# Altium schematic sheet 5_A9912FH-PCB2-PFC_MCU BOARD _V1.SchDoc("5_A9912FH-PCB2-PFC_MCU BOARD _V1")
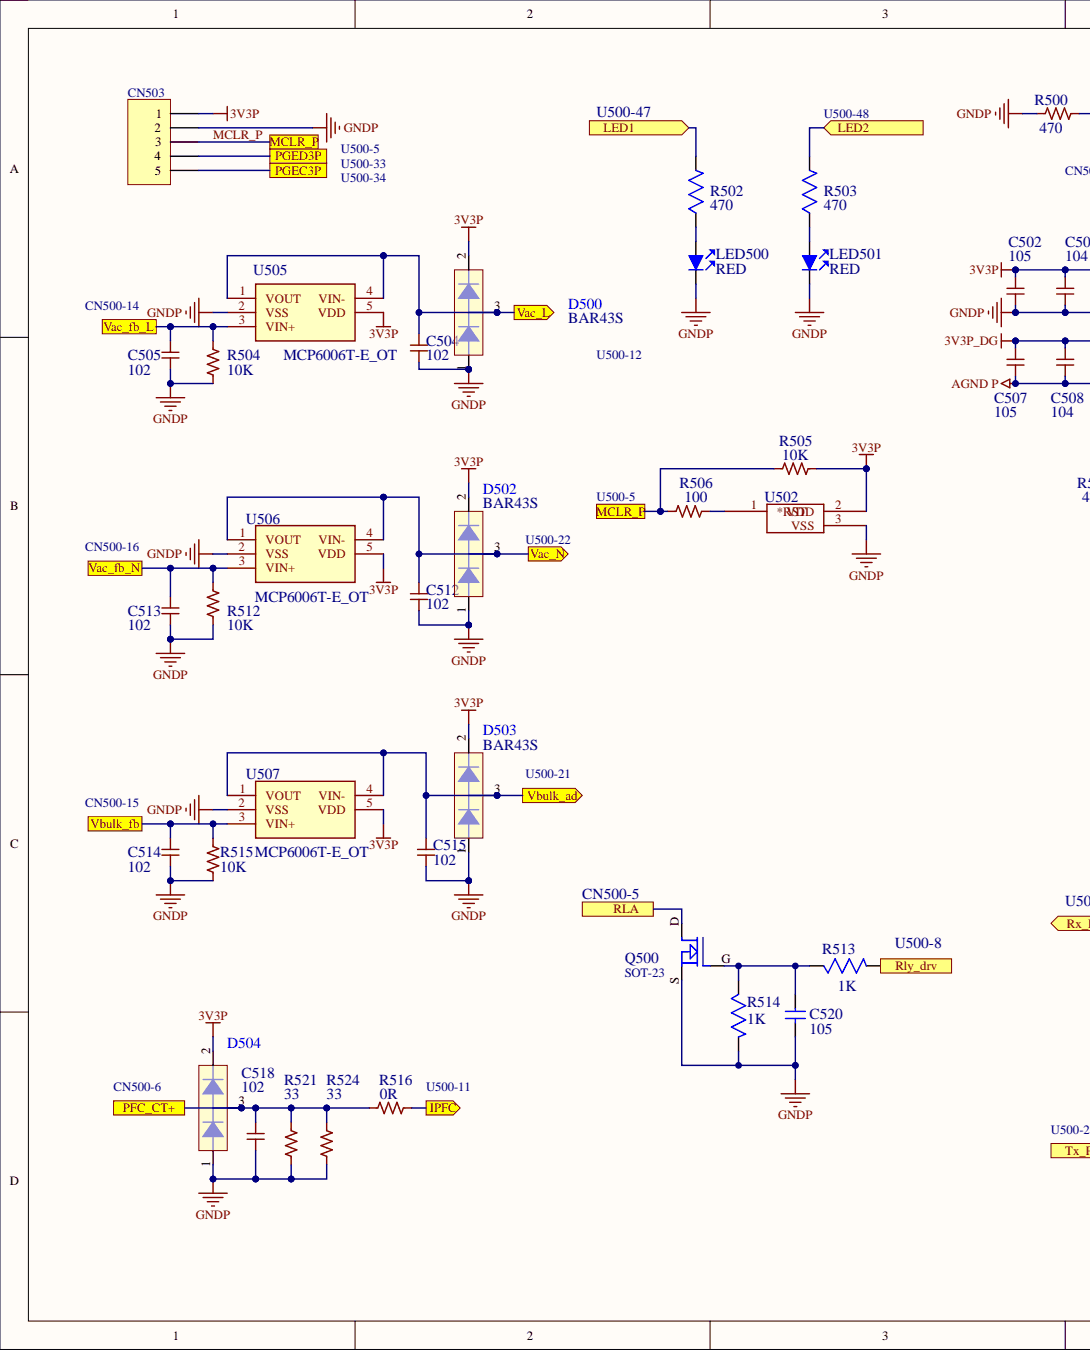
[diagram p0: 5_A9912FH-PCB2-PFC_MCU BOARD _V1.SchDoc, left]
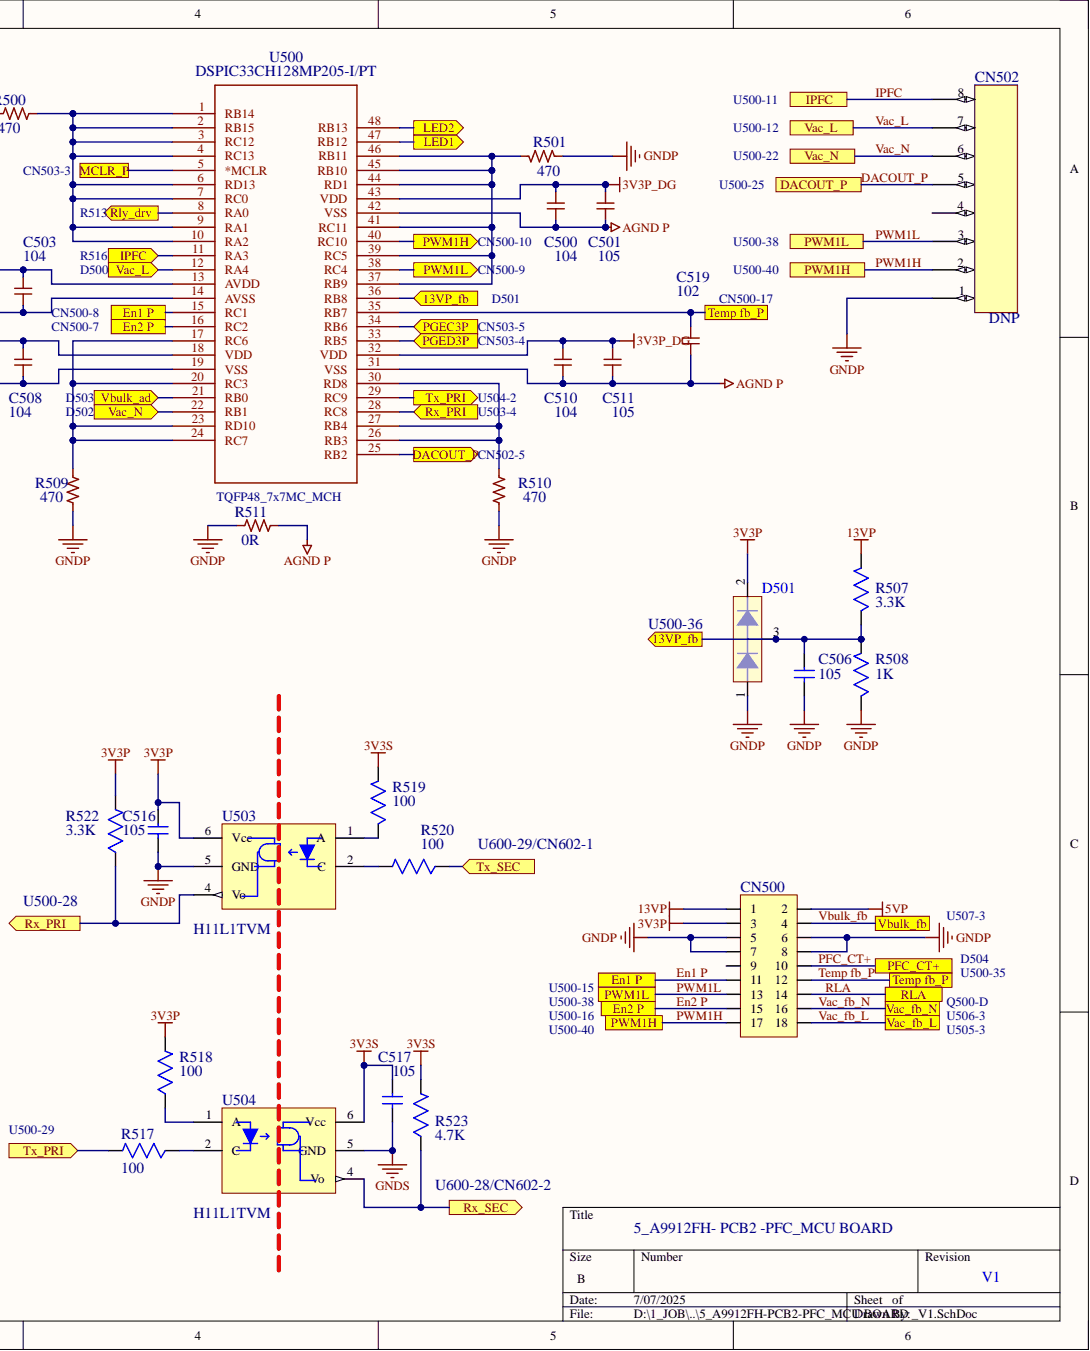
[diagram p1: 5_A9912FH-PCB2-PFC_MCU BOARD _V1.SchDoc, right]

## Parts
C500  value 104  (hidden comment: 1uF)
C501  value 105  (hidden comment: 0.1uF)
C502  value 105  (hidden comment: 0.1uF)
C503  value 104  (hidden comment: 1uF)
C504  value 102  (hidden comment: 1n)
C505  102
C506  value 105  [CS-0805]  (hidden comment: CAP_RC0603)
C507  value 105  (hidden comment: 0.1uF)
C508  value 104  (hidden comment: 1uF)
C510  value 104  (hidden comment: 1uF)
C511  value 105  (hidden comment: 0.1uF)
C512  value 102  (hidden comment: 1n)
C513  value 102  (hidden comment: 1n)
C514  value 102  (hidden comment: 1n)
C515  value 102  (hidden comment: 1n)
C516  value 105  [CS-0805]  (hidden comment: 0603_1uF 25V X7R)
C517  value 105  [CS-0805]  (hidden comment: 0603_1uF 25V X7R)
C518  value 102  (hidden comment: 1n)
C519  value 102  (hidden comment: 1n)
C520  value 105  [CS-0805]  (hidden comment: 0603_1uF 25V X7R)
CN500  CON18D  [CND-BH-P2_54-18H]  (value not drawn)
CN502  value DNP  [PN-P2_54-8]  (hidden comment: SIP_8_RA)
CN503  CON5  [PN-P2_54-5]  (value not drawn)
D500  BAR43S  [DS-SOT23-MMBD4148]
D501  BAR43S  [DS-SOT23-MMBD4148]  (value not drawn)
D502  BAR43S  [DS-SOT23-MMBD4148]
D503  BAR43S  [DS-SOT23-MMBD4148]
D504  BAR43S  [DS-SOT23-MMBD4148]  (value not drawn)
LED500  RED  [LEDS-HUB35A]
LED501  RED  [LEDS-HUB35A]
Q500  MOS-N  [MOS-SOT23 -GDS]  (value not drawn)
R500  470
R501  470
R502  value 470  [RS-0805]  (hidden comment: RC_0603)
R503  value 470  [RS-0805]  (hidden comment: RC_0603)
R504  10K
R505  10K
R506  100
R507  value 3.3K  [RS-0805]  (hidden comment: RC_0603)
R508  value 1K  [RS-0805]  (hidden comment: RC_0603)
R509  470
R510  470
R511  0  value 0R
R512  10K
R513  value 1K  [RS-0805]  (hidden comment: RC_0603)
R514  value 1K  [RS-0805]  (hidden comment: RC_0603)
R515  10K
R516  0  value 0R
R517  value 100  [RS-0805]  (hidden comment: RC_0603)
R518  value 100  [RS-0805]  (hidden comment: RC_0603)
R519  value 100  [RS-0805]  (hidden comment: RC_0603)
R520  value 100  [RS-0805]  (hidden comment: RC_0603)
R521  33
R522  value 3.3K  [RS-0805]  (hidden comment: RC_0603)
R523  value 4.7K  [RS-0805]  (hidden comment: RC_0603)
R524  33
U500  DSPIC33CH128MP205-I/PT  [TQFP48_7x7MC_MCH]
U502  MCP121T-300E_TT  [SOT-23_MC_MCH]  (value not drawn)
U503  H11L1TVM  [ICS-PDIP-6]
U504  H11L1TVM  [ICS-PDIP-6]
U505  MCP6006T-E_OT  [SOT95P280X145-5N]
U506  MCP6006T-E_OT  [SOT95P280X145-5N]
U507  MCP6006T-E_OT  [SOT95P280X145-5N]

## Ports
13VP_fb, DACOUT_P, En1 P, En2 P, IPFC, LED1, LED2, MCLR_P, PFC_CT+, PGEC3P, PGED3P, PWM1H, PWM1L, RLA, Rly_drv, Rx_PRI, Rx_SEC, Temp fb_P, Tx_PRI, Tx_SEC, Vac_fb_L, Vac_fb_N, Vac_L, Vac_N, Vbulk_ad, Vbulk_fb

## Nets
3V3P: C502.1 C503.1 C516.1 CN500.3 CN503.1 D500.2 D501.2 D502.2 D503.2 D504.2 R505.2 R518.1 R522.1 U500.13(AVDD) U502.2 U503.6(Vcc) U505.5(VDD) U506.5(VDD) U507.5(VDD)
3V3P_DG: C500.1 C501.1 C507.1 C508.1 C510.1 C511.1 U500.18(VDD) U500.32(VDD) U500.43(VDD)
3V3S: C517.1 R519.1 R523.1 U504.6(Vcc)
5VP: CN500.2
13VP: CN500.1 R507.1
AGND P: C500.2 C501.2 C507.2 C508.2 C510.2 C511.2 C519.2 R511.2 U500.19(VSS) U500.31(VSS) U500.42(VSS)
GNDP: C502.2 C503.2 C504.2 C505.2 C506.2 C512.2 C513.2 C514.2 C515.2 C516.2 C518.2 C520.2 CN500.5 CN500.6 CN500.7 CN500.8 CN502.1 CN503.2 D500.1 D501.1 D502.1 D503.1 D504.1 LED500.K LED501.K Q500.S R500.1 R501.1 R504.2 R508.2 R509.1 R510.1 R511.1 R512.2 R514.1 R515.2 R521.2 R524.2 U500.14(AVSS) U502.3 U503.5(GND) U505.2(VSS) U506.2(VSS) U507.2(VSS)
GNDS: C517.2 U504.5(GND)
13VP_fb: C506.1 D501.3 R507.2 R508.1 U500.36(RB8) ; port 13VP_fb
DACOUT_P: CN502.5 U500.25(RB2) ; port DACOUT_P
En1 P: CN500.11 U500.15(RC1) ; port En1 P
En2 P: CN500.15 U500.16(RC2) ; port En2 P
IPFC: CN502.8 R516.2 U500.11(RA3) ; port IPFC
LED1: R502.1 U500.47(RB12) ; port LED1
LED2: R503.1 U500.48(RB13) ; port LED2
MCLR_P: CN503.3 R505.1 R506.1 U500.5(*MCLR) ; port MCLR_P
PFC_CT+: C518.1 CN500.10 D504.3 R516.1 R521.1 R524.1 ; port PFC_CT+
PGEC3P: CN503.5 U500.34(RB6) ; port PGEC3P
PGED3P: CN503.4 U500.33(RB5) ; port PGED3P
PWM1H: CN500.17 CN502.2 U500.40(RC10) ; port PWM1H
PWM1L: CN500.13 CN502.3 U500.38(RC4) ; port PWM1L
RLA: CN500.14 Q500.D ; port RLA
Rly_drv: R513.1 U500.8(RA0) ; port Rly_drv
Rx_PRI: R522.2 U500.28(RC8) U503.4(Vo) ; port Rx_PRI
Rx_SEC: R523.2 U504.4(Vo) ; port Rx_SEC
Temp fb_P: C519.1 CN500.12 U500.35(RB7) ; port Temp fb_P
Tx_PRI: R517.1 U500.29(RC9) ; port Tx_PRI
Tx_SEC: R520.2 ; port Tx_SEC
Vac_fb_L: C505.1 CN500.18 R504.1 U505.3(VIN+) ; port Vac_fb_L
Vac_fb_N: C513.1 CN500.16 R512.1 U506.3(VIN+) ; port Vac_fb_N
Vac_L: C504.1 CN502.7 D500.3 U500.12(RA4) U505.1(VOUT) U505.4(VIN-) ; port Vac_L
Vac_N: C512.1 CN502.6 D502.3 U500.22(RB1) U506.1(VOUT) U506.4(VIN-) ; port Vac_N
Vbulk_ad: C515.1 D503.3 U500.21(RB0) U507.1(VOUT) U507.4(VIN-) ; port Vbulk_ad
Vbulk_fb: C514.1 CN500.4 R515.1 U507.3(VIN+) ; port Vbulk_fb
NetC520_1: C520.1 Q500.G R513.2 R514.2
NetLED500_A: LED500.A R502.2
NetLED501_A: LED501.A R503.2
NetR500_2: R500.2 U500.1(RB14) U500.2(RB15) U500.3(RC12) U500.4(RC13) U500.6(RD13) U500.7(RC0) U500.9(RA1) U500.10(RA2)
NetR501_2: R501.2 U500.37(RB9) U500.39(RC5) U500.41(RC11) U500.44(RD1) U500.45(RB10) U500.46(RB11)
NetR506_2: R506.2 U502.1
NetR509_2: R509.2 U500.17(RC6) U500.20(RC3) U500.23(RD10) U500.24(RC7)
NetR510_2: R510.2 U500.26(RB3) U500.27(RB4) U500.30(RD8)
NetR517_2: R517.2 U504.2(C)
NetR518_2: R518.2 U504.1(A)
NetR519_2: R519.2 U503.1(A)
NetR520_1: R520.1 U503.2(C)

Not connected: CN500 9; CN502 4

## Library data of the parts
C500-C505 C507 C508 C510-C515 C518 C519 = C_Small: Component Kind: Standard; Library Name: Device
  C505: Value: 102
C506 = 0603_1nF_50V: CAP CER 1000PF 50V 20% X7R 0603; Part Number: C0603C102M5RACTU; Vendor: Kemet; Component Kind: Standard; Digikey: 399-7837-1-ND; Price: 317
C516 C517 C520 = 0603_1uF 25V X7R: CAP CER 1UF 25V 10% X7R 0603; Part Number: C1608X7R1E105K; Vendor: TDK Corporation; Component Kind: Standard; Digikey: 445-5956-1-ND; Mouser: 810-C1608X7R1E105K; Price: 332
CN502 = SIP_8_RA: CONN HEADER 8POS .100" SGL GOLD RA; Part Number: TSW-108-08-G-S-RA; Other Source: Samtec Inc; Component Kind: Standard; Digikey: SAM1039-08-ND; Price: 84; Vendor: Samtec Inc
CN503 = CON5: Header, 5-Pin; Component Kind: Standard; LatestRevisionDate: 17-Jul-2002; LatestRevisionNote: Re-released for DXP Platform.; Library Name: CONNECT-SCH.SchLib; Publisher: Altium Limited
D500-D504 = CMP-004-00019-2: Dual small signal switching diode - SOT23; Alternative accepted: Soft check; PN Egg: A-D-BAV99-SOT23; Value: BAV99; Component Kind: Standard; Termination Style: SMD; Type: Switching; Library Name: Eggtronic Engineering Pro on A365
LED500 LED501 = LED: Component Kind: Standard; Description: LED
R500 R501 R504-R506 R509-R512 R515 R516 R521 R524 = R_US: Component Kind: Standard; Library Name: Device
  R500 R501 R509 R510: Value: 470
  R504 R505 R512 R515: Value: 10K
  R506: Value: 100
  R521 R524: Value: 33
R502 R503 = 0603_330 Ohm: RES 330 OHM 1/10W 1% 0603 SMD; Part Number: RMCF 1/16 330 1% R; Vendor: Stackpole Electronics Inc; Component Kind: Standard; Digikey: RMCF1/16330FRTR-ND; Price: 228
R507 R522 = 0603_3.3K: RES 3.3K OHM 1/10W 1% 0603 SMD; Part Number: RMCF0603FT3K30; Vendor: Stackpole Electronics Inc; Component Kind: Standard; Digikey: RMCF0603FT3K30CT-ND; Price: 228
R508 R513 R514 = 0603_1K: RES 1K OHM 1/10W 1% 0603 SMD; Part Number: RMCF 1/16 1K 1% R; Vendor: Stackpole Electronics Inc; Component Kind: Standard; Digikey: RMCF1/161KFRTR-ND; Price: 228
R517-R520 = 0603_100 Ohm: RES 100 OHM 1/10W 1% 0603 SMD; Part Number: RMCF 1/16 100 1% R; Vendor: Stackpole Electronics Inc; Component Kind: Standard; Digikey: RMCF1/16100FRTR-ND; Price: 228
R523 = 0603_4.7K: RES 4.7K OHM 1/10W 1% 0603 SMD; Part Number: RMCF 1/16 4.7K 1% R; Vendor: Stackpole Electronics Inc; Component Kind: Standard; Digikey: RMCF1/164.7KFRTR-ND; Price: 228
U500 = DSPIC33CH128MP205-I_PT: Component Kind: Standard; Value: DSPIC33CH128MP205-I/PT; Library Name: amond_library
U503 U504 = H11L1TVM: OPTOCOUPLER SCHMITT-TR VDE 6-DIP; Vendor: Fairchild Semiconductor; Component Kind: Standard; Digikey: H11L1TVM-ND; Mouser: 512-FOD817SD; Part Number: H11L1TVM; price: 7403
U505-U507 = MCP6006T-E_OT: Manufacturer_Name: Microchip; Manufacturer_Part_Number: MCP6006T-E/OT; Arrow Part Number: MCP6006T-E/OT; Arrow Price/Stock: null?region=nac; Component Kind: Standard; Height: 1.45; Mouser Part Number: 579-MCP6006T-E/OT; Mouser Price/Stock: https://www.mouser.co.uk/ProductDetail/Microchip-Technology/MCP6006T-E-OT?qs=DRkmTr78QATyRc70SgbX1g%3D%3D; Value: MCP6006T-E_OT; Library Name: amond_library
CN500 = CON18D: Component Kind: Standard
Q500 = MOS-N: Component Kind: Standard; Description: MOS-N; Library Name: MOS&TRANSISTOR-SCH.SchLib
U502 = MCP121T-300E_TT: Component Kind: Standard; Value: MCP121T-300E_TT; Library Name: amond_library
